# Altium schematic sheet CAN and I2C.SchDoc
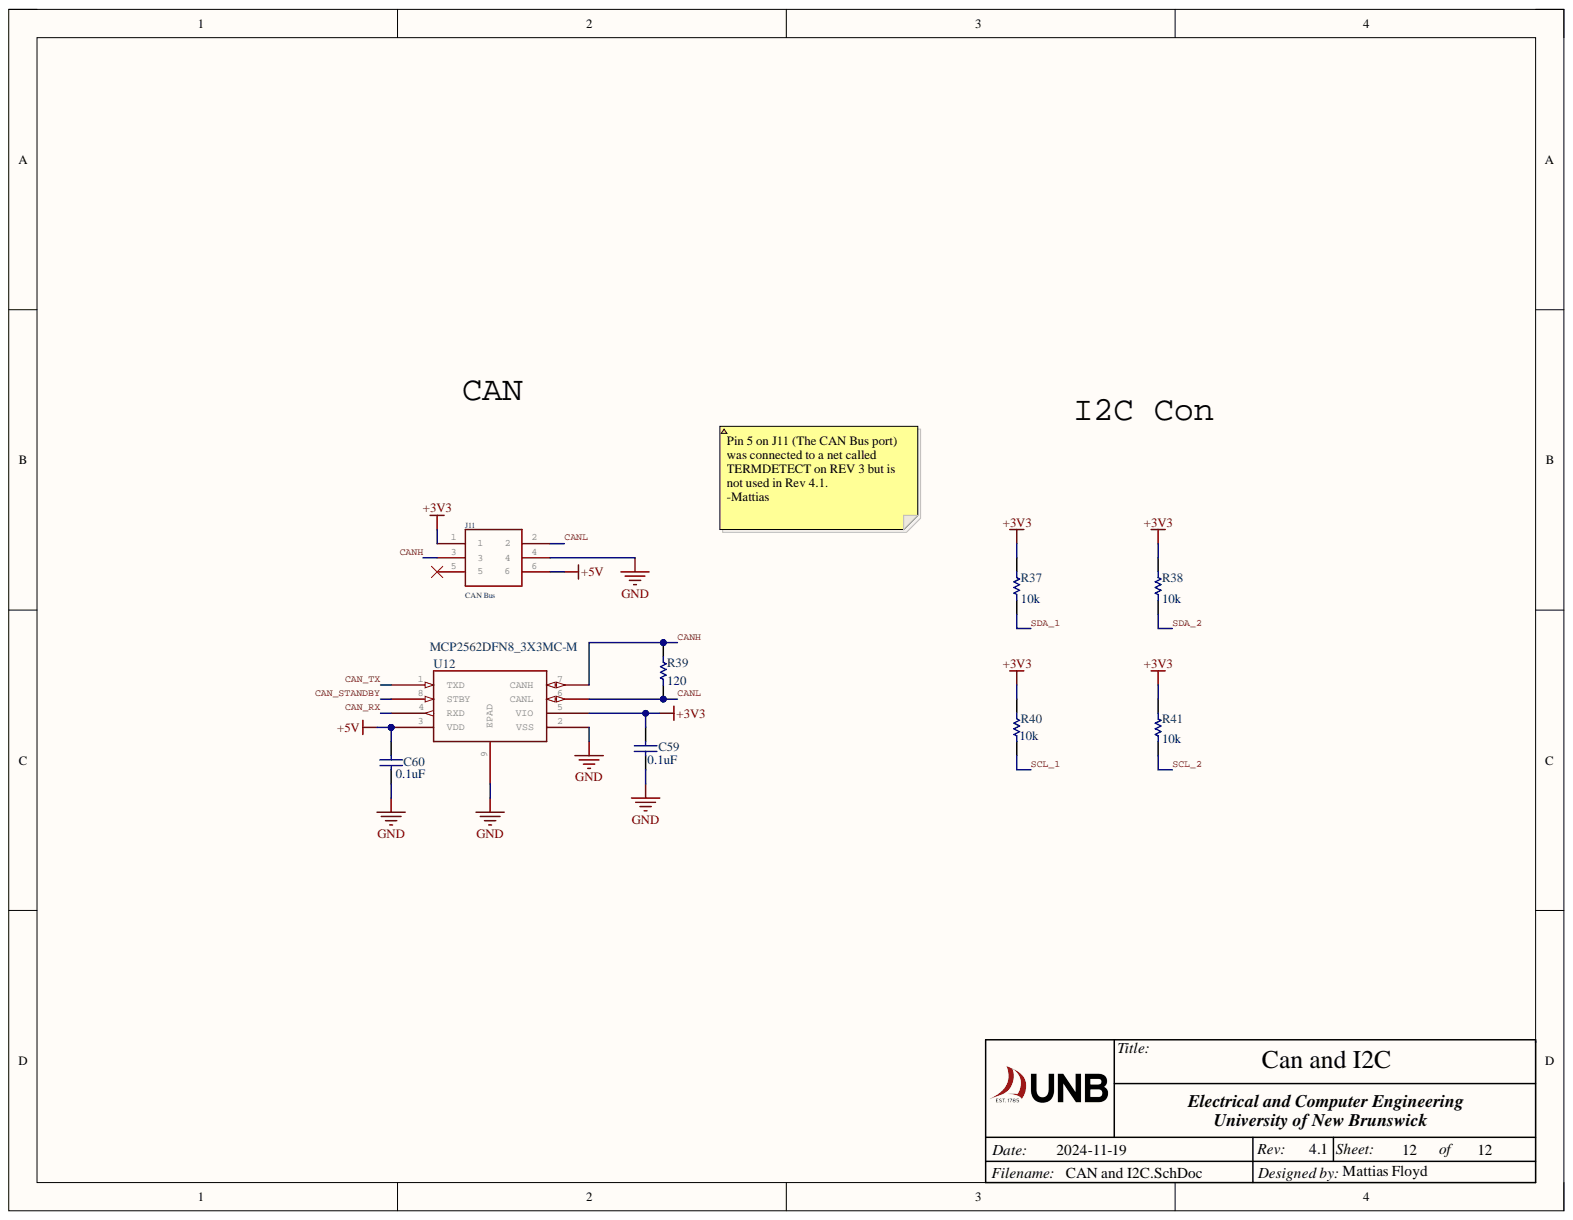
[diagram p0: CAN and I2C.SchDoc]

## Parts
C59  0.1uF  [0603 Cap]
C60  0.1uF  [0603 Cap]
J11  CAN Bus  [615006138421]
R37  10k  [0603 Resistor]
R38  10k  [0603 Resistor]
R39  120  [0603 Resistor]
R40  10k  [0603 Resistor]
R41  10k  [0603 Resistor]
U12  MCP2562DFN8_3X3MC-M  [DFN8_3X3MC-M]

## Nets
+3V3: C59.2 J11.1 R37.1 R38.1 R40.1 R41.1 U12.5(VIO)
+5V: C60.2 J11.6 U12.3(VDD)
GND: C59.1 C60.1 J11.4 U12.2(VSS) U12.9(EPAD)
CAN_RX: U12.4(RXD)
CAN_STANDBY: U12.8(STBY)
CAN_TX: U12.1(TXD)
CANH: J11.3 R39.1 U12.7(CANH)
CANL: J11.2 R39.2 U12.6(CANL)
SCL_1: R40.2
SCL_2: R41.2
SDA_1: R37.2
SDA_2: R38.2

Not connected: J11 5

## Library data of the parts
C59 C60 = Cap Semi: Cap Ceramic 0.1uF 50VDC X7R 10% SMD 0603 Paper T/R; Manufacturer: Samsung; Manufacturer Part Number: CL10B104KB8NNNC; Capacitance: 100nF; Voltage: 50V; Case Code (Imperial): 0603; Case Code (Metric): 1608; Case/Package: 0603; Category: Ceramic Capacitors; Component Kind: Standard; ComponentLink1Description: http://www.avx.com/docs/Catalogs/cx7r.pdf; ComponentLink1URL: http://www.avx.com/docs/Catalogs/cx7r.pdf; ComponentLink2Description: https://datasheet.ciiva.com/25803/cl10b104kb8nnnc-25803393.pdf?src-supplier=Digi-Key; ComponentLink2URL: https://datasheet.ciiva.com/25803/cl10b104kb8nnnc-25803393.pdf?src-supplier=Digi-Key; ComponentLink3Description: https://datasheet.ciiva.com/26838/getdatasheetpartid-89097-26838411.pdf?src-supplier=Verical; ComponentLink3URL: https://datasheet.ciiva.com/26838/getdatasheetpartid-89097-26838411.pdf?src-supplier=Verical; ComponentLink4Description: https://datasheet.ciiva.com/29198/456651-da-01-en-vs-kondensator-0605-29198839.pdf?src-supplier=Conrad; ComponentLink4URL: https://datasheet.ciiva.com/29198/456651-da-01-en-vs-kondensator-0605-29198839.pdf?src-supplier=Conrad; Contact Plating: Tin; Depth: 0.8mm; Dielectric: X7R; Height: 0.8mm; Lead Free: Lead Free; Length: 1.6mm; Library Name: CAN_Interface_Library.SCHLIB; Material: Ceramic; Max Operating Temperature: 125°C; Min Operating Temperature: -55°C; Mount: Surface Mount; Package Quantity: 1; Packaging: Tape and Reel; Radiation Hardening: No; RoHS: Yes; Signal Integrity: Cap Semi; Simulation: CAP; Stock 1: 17879275; Supplier 1: Digi-Key; Supplier Part Number 1: 1276-1000-6-ND; Thickness: 0.035inch; Tolerance: 10%; Value: 0.1uF; Voltage Rating: 50V; Voltage Rating (DC): 50V; Width: 0.031inch
J11 = 615006138421: WURTH ELEKTRONIK - 615006138421 - Modular Connector, Modular Jack, 1 x 1 (Port), 6P6C, Through Hole Mount; Manufacturer: Wurth Electronics; Connector Type: Jack,Socket; Current Rating: 1.5A; Direction: Up; Gender: Female; Insulation Resistance: 500MR; Mating Cycles: 500; Number of Contacts: 6; Number of Ports: 1; Shielding: Unshielded; Voltage Rating (AC): 120V; Category: Connectors; Color: Black; Component Kind: Standard; ComponentLink1Description: https://datasheet.ciiva.com/11726/0900766b80f88670-11726196.pdf?src-supplier=RSComponents; ComponentLink1URL: https://datasheet.ciiva.com/11726/0900766b80f88670-11726196.pdf?src-supplier=RSComponents; ComponentLink2Description: https://datasheet.ciiva.com/4561/615006138421-83644-4561637.pdf?src-supplier=Mouser; ComponentLink2URL: https://datasheet.ciiva.com/4561/615006138421-83644-4561637.pdf?src-supplier=Mouser; ComponentLink3Description: https://datasheet.ciiva.com/685/615006138421-685815.pdf?src-supplier=Digi-Key; ComponentLink3URL: https://datasheet.ciiva.com/685/615006138421-685815.pdf?src-supplier=Digi-Key; ComponentLink4Description: https://datasheet.ciiva.com/1108/624470-1108092.pdf?src-supplier=Element14; ComponentLink4URL: https://datasheet.ciiva.com/1108/624470-1108092.pdf?src-supplier=Element14; ComponentLink5Description: https://datasheet.ciiva.com/6913/76611-6913948.pdf?src-supplier=Newark; ComponentLink5URL: https://datasheet.ciiva.com/6913/76611-6913948.pdf?src-supplier=Newark; ComponentLink6Description: https://datasheet.ciiva.com/14118/4257070-eng-datasheet-14118887.pdf?src-supplier=Distrelec; ComponentLink6URL: https://datasheet.ciiva.com/14118/4257070-eng-datasheet-14118887.pdf?src-supplier=Distrelec; Contact Material: Copper Alloy,Copper; Contact Plating: Gold; Depth: 13mm; Flammability Rating: UL94 V-0; Height: 17.7mm; Housing Material: PBT; Length: 12.2mm; Manufacturer Part Number: 615006138421; Max Operating Temperature: 85°C; Min Operating Temperature: -40°C; Mount: Through Hole; Number of Positions: 6; Number of Rows: 1; Orientation: Right Angle; Package Quantity: 120; Packaging: Tray; REACH SVHC: Unknown; RoHS: Yes; Stock 1: 8363; Supplier 1: Digi-Key; Supplier Part Number 1: 732-2113-ND; Termination: Through Hole; Width: 13mm; Library Name: BrilliantLabsEagleLibrary.IntLib
R37 R38 R40 R41 = Res Semi: Res Thick Film 0603 10K Ohm 1% 0.1W(1/10W) ±100ppm/C Molded SMD Automotive T/R; Manufacturer: Stackpole Electronics; Manufacturer Part Number: RMCF0603FT10K0; Max Power Dissipation: 100mW; Resistance: 10kR; Temperature Coefficient: 100ppm/°C; Case Code (Imperial): 0603; Case Code (Metric): 1608; Case/Package: 0603; Category: Chip SMD Resistors; Component Kind: Standard; ComponentLink1Description: http://www.rohm.com/web/global/datasheet/ESR01MZPF/esr-e; ComponentLink1URL: http://www.rohm.com/web/global/datasheet/ESR01MZPF/esr-e; ComponentLink2Description: http://components.arrow.com/part/dataview/43747082S6756917N1174?src-supplier=Arrow+Electronics; ComponentLink2URL: http://components.arrow.com/part/dataview/43747082S6756917N1174?src-supplier=Arrow+Electronics; ComponentLink3Description: https://www.seielect.com/catalog/sei-rmcf_rmcp.pdf?src-supplier=Digi-Key; ComponentLink3URL: https://www.seielect.com/catalog/sei-rmcf_rmcp.pdf?src-supplier=Digi-Key; ComponentLink4Description: https://www.seielect.com/catalog/SEI-RMCF_RMCP.pdf?src-supplier=Avnet; ComponentLink4URL: https://www.seielect.com/catalog/SEI-RMCF_RMCP.pdf?src-supplier=Avnet; ComponentLink5Description: http://www.seielect.com/catalog/sei-rmc.pdf?src-supplier=Future+Electronics; ComponentLink5URL: http://www.seielect.com/catalog/sei-rmc.pdf?src-supplier=Future+Electronics; ComponentLink6Description: https://www.verical.com/server-webapp/html/getDatasheet?partID=360913&src-supplier=Verical; ComponentLink6URL: https://www.verical.com/server-webapp/html/getDatasheet?partID=360913&src-supplier=Verical; Composition: Thick Film; Contact Plating: Tin,Matte; Depth: 0.8mm; Features: Automotive AEC-Q200; Height: 0.022inch; Lead Free: Lead Free; Length: 1.55mm; Max Operating Temperature: 155°C; Military Standard: Not; Min Operating Temperature: -55°C; Mount: Surface Mount; Number of Terminations: 2; Packaging: Tape and Reel; Power Rating: 100mW; Radiation Hardening: No; RoHS: Yes; Signal Integrity: Res Semi; Simulation: RES; Stock 1: 1608506; Supplier 1: Digi-Key; Supplier Part Number 1: RMCF0603FT10K0CT-ND; Tolerance: 1%; Value: 10k; Width: 0.031inch; Library Name: BrillianBit.SCHLIB
R39 = Res Semi: Res Thick Film 0603 120 Ohm 1% 0.1W(1/10W) ±100ppm/C Molded SMD Automotive T/R; Manufacturer: Stackpole Electronics; Manufacturer Part Number: RMCF0603FT120R; Max Power Dissipation: 100mW; Resistance: 120R; Temperature Coefficient: 100ppm/°C; Case Code (Imperial): 0603; Case Code (Metric): 1608; Case/Package: 0603; Category: Chip SMD Resistors; Component Kind: Standard; ComponentLink1Description: http://www.rohm.com/web/global/datasheet/ESR01MZPF/esr-e; ComponentLink1URL: http://www.rohm.com/web/global/datasheet/ESR01MZPF/esr-e; ComponentLink2Description: https://www.verical.com/server-webapp/html/getDatasheet?partID=503576&src-supplier=Verical; ComponentLink2URL: https://www.verical.com/server-webapp/html/getDatasheet?partID=503576&src-supplier=Verical; ComponentLink3Description: http://components.arrow.com/part/dataview/46949903S7670706N1174?src-supplier=Arrow+Electronics; ComponentLink3URL: http://components.arrow.com/part/dataview/46949903S7670706N1174?src-supplier=Arrow+Electronics; ComponentLink4Description: https://www.seielect.com/catalog/SEI-RMCF_RMCP.pdf?src-supplier=Avnet; ComponentLink4URL: https://www.seielect.com/catalog/SEI-RMCF_RMCP.pdf?src-supplier=Avnet; ComponentLink5Description: https://www.seielect.com/catalog/SEI-Packaging.pdf?src-supplier=Digi-Key; ComponentLink5URL: https://www.seielect.com/catalog/SEI-Packaging.pdf?src-supplier=Digi-Key; ComponentLink6Description: https://www.seielect.com/Catalog/SEI-RMCF_RMCP.pdf?src-supplier=Digi-Key; ComponentLink6URL: https://www.seielect.com/Catalog/SEI-RMCF_RMCP.pdf?src-supplier=Digi-Key; Composition: Thick Film; Contact Plating: Tin,Matte; Depth: 0.8mm; Features: Automotive AEC-Q200; Height: 0.022inch; Lead Free: Lead Free; Length: 0.061inch; Max Operating Temperature: 155°C; Military Standard: Not; Min Operating Temperature: -55°C; Mount: Surface Mount; Number of Terminations: 2; Packaging: Tape and Reel; Radiation Hardening: No; RoHS: Yes; Signal Integrity: Res Semi; Simulation: RES; Stock 1: 284932; Supplier 1: Digi-Key; Supplier Part Number 1: RMCF0603FT120RCT-ND; Tolerance: 1%; Value: 120; Width: 0.031inch; Library Name: BrillianBit.SCHLIB
U12 = MCP2562DFN8_3X3MC-M: CAN Interface IC; Manufacturer: Microchip; Manufacturer Part Number: MCP2562T-E/MF; Category: Interface ICs; Component Kind: Standard; ComponentLink1Description: https://datasheet.ciiva.com/18624/25167b-18624809.pdf?src-supplier=Digi-Key; ComponentLink1URL: https://datasheet.ciiva.com/18624/25167b-18624809.pdf?src-supplier=Digi-Key; ComponentLink2Description: https://datasheet.ciiva.com/29898/25167b-29898010.pdf?src-supplier=Avnet; ComponentLink2URL: https://datasheet.ciiva.com/29898/25167b-29898010.pdf?src-supplier=Avnet; RoHS: Yes; Stock 1: 5466; Supplier 1: Digi-Key; Supplier Part Number 1: MCP2562T-E/MFCT-ND; Library Name: BrilliantLabsEagleLibrary.IntLib
